# Altium schematic sheet CAN.SchDoc(CAN)
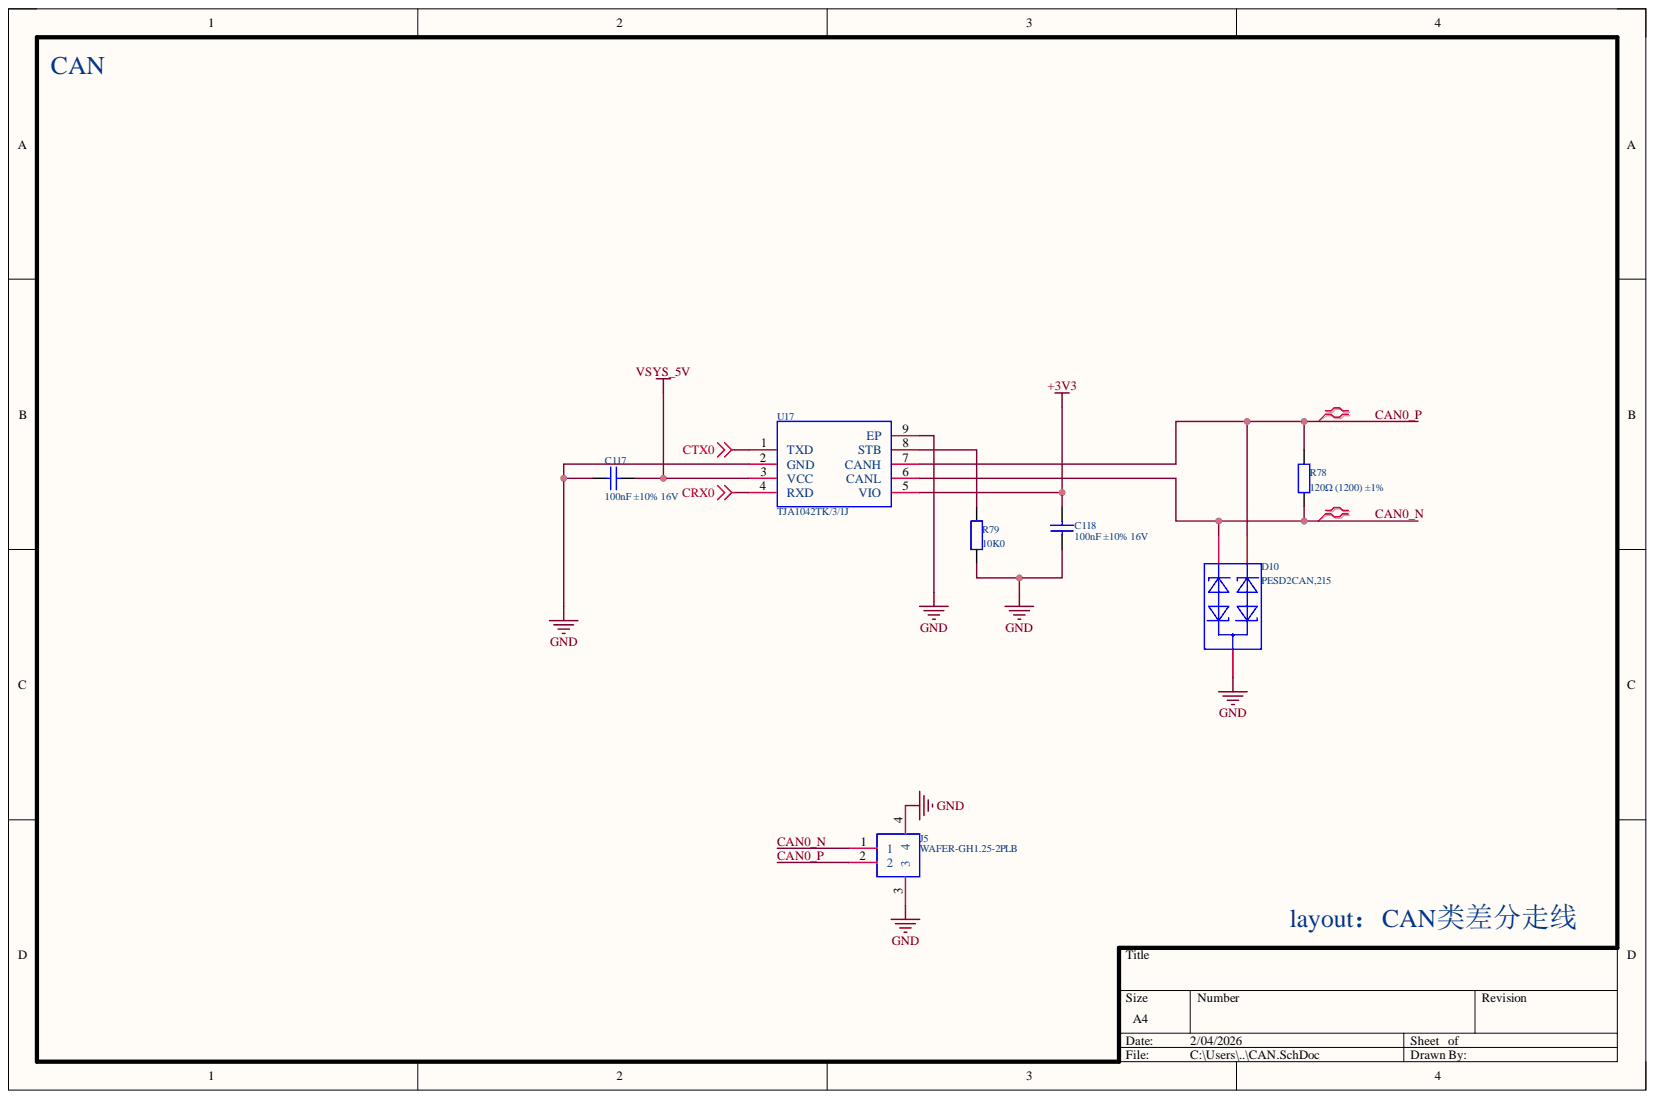
[diagram p0: CAN.SchDoc]

## Parts
C117  100nF ±10% 16V  Capacitance: 100nF  Voltage: 16V  [C0201]
C118  100nF ±10% 16V  Capacitance: 100nF  [C0201]
D10  PESD2CAN,215  [SOT-23-3_L2.9-W1.3-P1.90-LS2.4-BR]
J5  WAFER-GH1.25-2PLB  [CONN-TH_2P-P1.25_XUNPU_WAFER-GH1.25-2PLB]
R78  120Ω (1200) ±1%  [R0402]
R79  10K0  [R0402]
U17  TJA1042TK/3/1J  [HVSON-8_L3.0-W3.0-P0.65-BL-EP]

## Nets
+3V3: C118.2 U17.5(VIO)
CRX0: U17.4(RXD)
CTX0: U17.1(TXD)
GND: C117.1 C118.1 D10.3 J5.3 J5.4 R79.2 U17.2(GND) U17.9(EP)
VSYS_5V: C117.2 U17.3(VCC)
CAN0_N: D10.2 J5.1 R78.2 U17.6(CANL)
CAN0_P: D10.1 J5.2 R78.1 U17.7(CANH)
NetR79_1: R79.1 U17.8(STB)

## Library data of the parts
C117 C118 = CAP-000030-01: 0201,100nF ±10% 16V，X7R; Legacy Part Number: 151-10004022; Manufacturer: muRata; Manufacturer Part Number 1: GRM033Z71C104KE14D; Dialectric: X7R; Notes: ANY EQUIVALENT; ClassName: MCU; Component Kind: Standard; ComponentLink1Description: New link; ComponentLink1URL: https://item.szlcsc.com/698285.html?fromZone=l_c__%2522catalog%2522&spm=sc.cid.xh3.zy.n___sc.gb.xh1.zy.l&lcsc_vid=FgdZAQZURgVfVlRQQQNbBAcFQVZYX1NeQ1BYX10ARFkxVlNSQ1FZUVReQVdaUjsOAxUeFF5JWAgaAglIBBsCBBcFWQIBCks%3D; Library Name: RA8P1.SCHLIB; Manufacturer 1: muRata; Manufacturer 3: AVX; Manufacturer Part Number 3: 0402YC104KAT2A; Mfg: muRata; Mfg P/N: GRM033Z71C104KE14D; Mfg Part Number: GRM033Z71C104KE14D; Package: 0402; PN: GRM033Z71C104KE14D; Supplier 1: Arrow Electronics; Supplier 2: Digi-Key; Supplier 3: Newark; Supplier 4: Mouser; Supplier Part Number 1: CC0402KRX7R8BB104; Supplier Part Number 2: 311-2077-1-ND; Supplier Part Number 3: 40AJ6370; Supplier Part Number 4: 603-CC402KRX7R8BB104; Tolerance: 10%
  C118: Voltage: 16V
D10 = PESD2CAN,215: Manufacturer: Nexperia(安世); Add into BOM: yes; Breakdown Voltage: 26.2V; Maximum Clamping Voltage: 41V; Number of Lines: 双路; Peak Pulse Current (Ipp)@10/1000us: 5A; Peak Pulse Power Dissipation (Ppp)@10/1000us: 230W; polarity: 双向; Reverse Stand-Off Voltage (Vrwm): 24V; Type: ESD; Component Kind: Standard; Convert to PCB: yes; Datasheet: https://atta.szlcsc.com/upload/public/pdf/source/20170505/1493951386298.pdf; Device: PESD2CAN,215; JLCPCB Part Class: Extended Part; LCSC Part Name: 双向ESD; Library Name: ra8p1_v0.1.SCHLIB; Manufacturer Part: PESD2CAN,215; Operating Temperature: -55℃~+150℃@(Ta); PCB3D: SOT-23-3P_L2.9-W1.3-H1.0-LS2.4-P0.95; Supplier: LCSC; Supplier Footprint: SOT-23; Supplier Part: C75176; Symbol: PESD2CAN,215
J5 = WAFER-GH1.25-2PLB: 立式; Manufacturer: XUNPU(讯普); Add into BOM: yes; Current Rating: 1A; Number of PINs Per Row: 2; Pins Structure: 1x2P; Pitch: 1.25mm; Plastic Material: LCP; Reference Series: GH; Supplementary Features: 辅助焊脚; Voltage Rating: 125V; X-Length of Bottom Edge on Board (Spacing Line): 5.75mm; Y-Width of Bottom Edge on Board: 4.95mm; Z-Height of the Board: 4.2mm; Color: 米色; Component Kind: Standard; Contact Material: 黄铜; Contact Plating: -; Convert to PCB: yes; Datasheet: https://atta.szlcsc.com/upload/public/pdf/source/20220527/44F824AAC8C2B617205E15955FF9C23D.pdf; Device: WAFER-GH1.25-2PLB; Flame Retardant Rating: -; JLCPCB Part Class: Extended Part; LCSC Part Name: 1x2P 间距:1.25mm 立贴 系列:GH; Library Name: ra8p1_v0.1.SCHLIB; Manufacturer Part: WAFER-GH1.25-2PLB; Mounting Type: 立贴; Number of Pins: 2P; Number of Rows: 1; Operating Temperature: -25℃~+85℃; PCB3D: CONN-TH_2P-P1.25_XUNPU_WAFER-GH1.25-2PLB; Row Spacing: -; Supplier: LCSC; Supplier Footprint: SMD,P=1.25mm; Supplier Part: C3029368; Symbol: WAFER-GH1.25-2PLB
R78 = 0402 120Ω (1200) 1%: 贴片电阻; Component Kind: Standard; ComponentLink1Description: 供应商链接; ComponentLink1URL: http://www.szlcsc.com/product/details_25822.html; Library Name: RES.SchLib; Package: 0402; Supplier: LC; SuppliersPartNumber: C25079
R79 = RES-100099-01: Chip Resistor Thick Film 0402 10K0 ohm 1% 1/16W; Manufacturer: Yageo; Manufacturer Part Number 1: RC0402FR-0710KP; Notes: ANY EQUIVALENT; Component Kind: Standard; Library Name: ra8p1_renesas.SCHLIB; Manufacturer 1: Yageo; Manufacturer 2: Samsung Electro-Mechanics; Manufacturer 3: Stackpole Electronics Inc.; Manufacturer Part Number 2: RC1005F103CS; Manufacturer Part Number 3: RMCF0402FT10K0; Mfg: Yageo; Mfg Part Number: RC0402FR-0710KP; Package: 0402; PN: RC0402FR-0710KP; Power: 1/16W; Resistance: 10K0; Supplier 1: Digi-Key; Supplier 2: Digi-Key; Supplier 3: Digi-Key; Supplier Part Number 1: YAG1249CT-ND; Supplier Part Number 2: 1276-3431-1-ND; Supplier Part Number 3: RMCF0402FT10K0CT-ND; Tolerance: 1%
U17 = TJA1042TK/3/1J: CAN收发器，DFN-8; Manufacturer: NXP(恩智浦); Add into BOM: yes; Data Rate: 5Mbps; Supply Voltage: 4.5V~5.5V; Component Kind: Standard; Convert to PCB: yes; Datasheet: https://www.nxp.com/docs/en/data-sheet/TJA1042.pdf; Device: TJA1042TK/3/1J; JLCPCB Part Class: Extended Part; LCSC Part Name: 5Mbps 4.5V~5.5V; Library Name: ra8p1_v0.1.SCHLIB; Manufacturer Part: TJA1042TK/3/1J; PCB3D: HVSON-8_L3.0-W3.0-H0.85-P0.65-BL-EP; Supplier: LCSC; Supplier Footprint: HVSON-8-EP(3x3); Supplier Part: C131480; Symbol: TJA1042TK/3/1J; Type: -
